# Altium schematic sheet mems.SchDoc(mems)
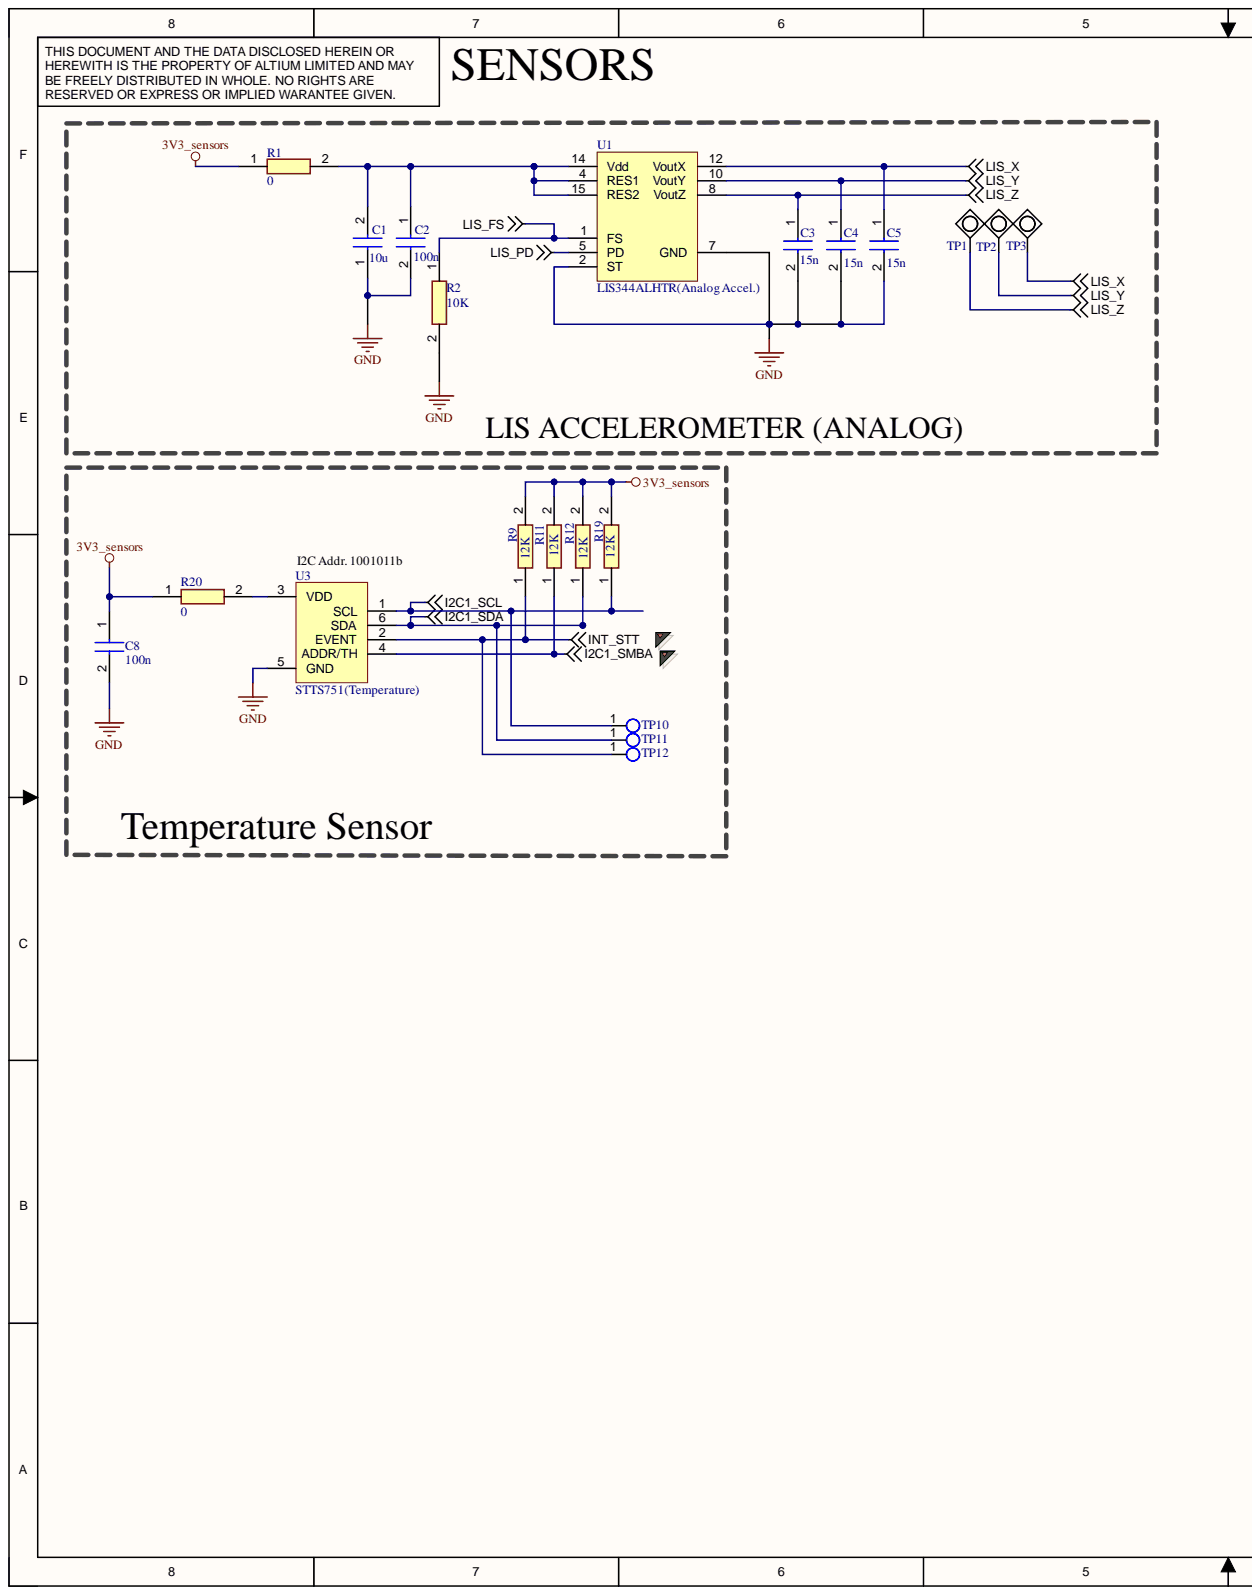
[diagram p0: mems.SchDoc, left]
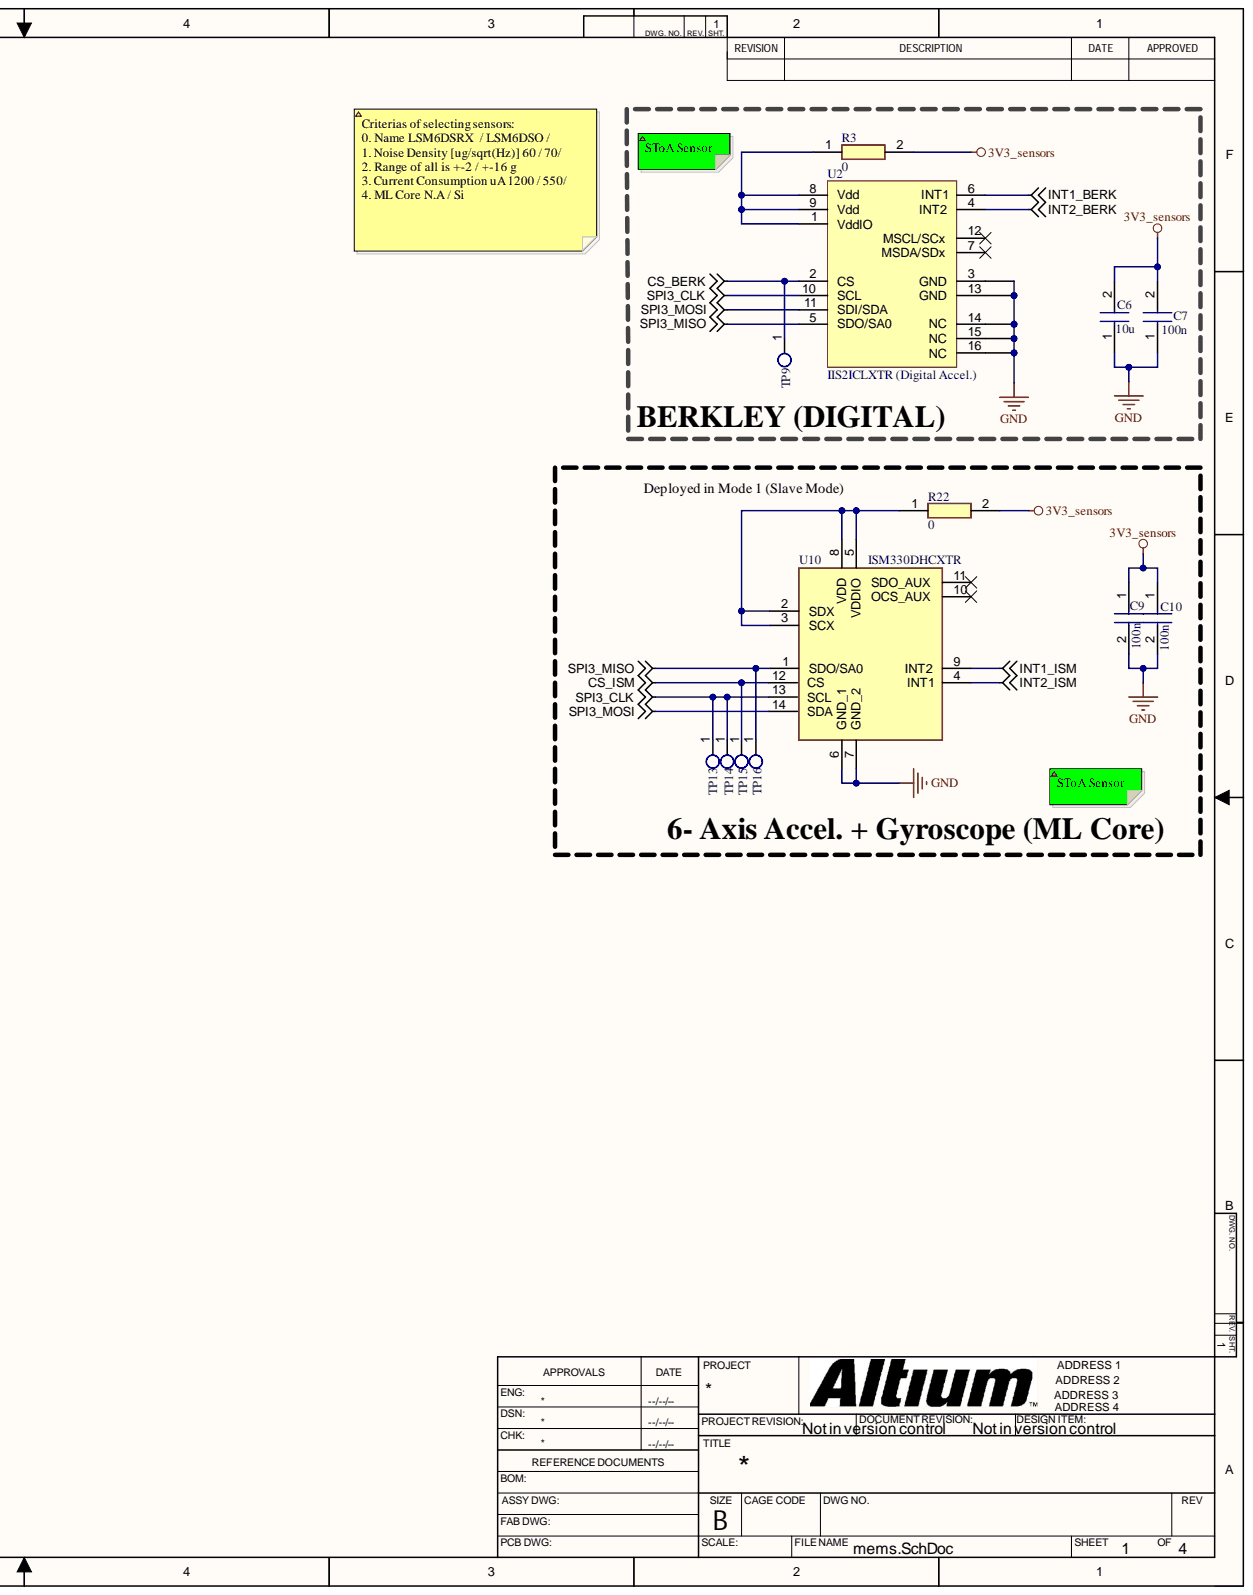
[diagram p1: mems.SchDoc, right]

## Parts
C1  10u  [CAPC1608X100N]
C2  100n  [CAPC1608X87N]
C3  15n  [CAPC1608X87N]
C4  15n  [CAPC1608X87N]
C5  15n  [CAPC1608X87N]
C6  10u  [CAPC1608X100N]
C7  100n  [CAPC1608X87N]
C8  100n  [CAPC1608X87N]
C9  100n  [CAPC1608X87N]
C10  100n  [CAPC1608X87N]
R1  0  [RESC1608X55N]
R2  10K  [ERJ3EK_(0603)]
R3  0  [RESC1608X55N]
R9  12K  [RESC0603X26N]
R11  12K  [RESC0603X26N]
R12  12K  [RESC0603X26N]
R19  12K  [RESC0603X26N]
R20  0  [RESC1608X55N]
R22  0  [RESC1608X55N]
TP1  Test Point  [KEYSTONE_5001]  (value not drawn)
TP2  Test Point  [KEYSTONE_5001]  (value not drawn)
TP3  Test Point  [KEYSTONE_5001]  (value not drawn)
TP9  test_point  [test_point]  (value not drawn)  (no BOM)
TP10  test_point  [test_point]  (value not drawn)  (no BOM)
TP11  test_point  [test_point]  (value not drawn)  (no BOM)
TP12  test_point  [test_point]  (value not drawn)  (no BOM)
TP13  test_point  [test_point]  (value not drawn)  (no BOM)
TP14  test_point  [test_point]  (value not drawn)  (no BOM)
TP15  test_point  [test_point]  (value not drawn)  (no BOM)
TP16  test_point  [test_point]  (value not drawn)  (no BOM)
U1  LIS344ALHTR(Analog Accel.)  [LGA16R65P4X4_400X400X160]
U2  IIS2ICLXTR (Digital Accel.)
U3  STTS751(Temperature)  [SOT95P280X145-6N]
U10  ISM330DHCXTR  [LGA-14(2.5X3X0.86)]

## Nets
3V3_sensors: C6.2 C7.2 C8.1 C9.1 C10.1 R1.1 R3.2 R9.2 R11.2 R12.2 R19.2 R20.1 R22.2
CS_BERK: TP9.1 U2.2(CS)
CS_ISM: TP15.1 U10.12(CS)
GND: C1.1 C2.2 C3.2 C4.2 C5.2 C6.1 C7.1 C8.2 C9.2 C10.2 R2.2 U1.2(ST) U1.7(GND) U2.3(GND) U2.13(GND) U2.14(NC) U2.15(NC) U2.16(NC) U3.5(GND) U10.6(GND_1) U10.7(GND_2)
I2C1_SCL: R19.1 TP10.1 U3.1(SCL)
I2C1_SDA: R12.1 TP11.1 U3.6(SDA)
I2C1_SMBA: R11.1 U3.4(ADDR/TH)
INT1_BERK: U2.6(INT1)
INT1_ISM: U10.9(INT2)
INT2_BERK: U2.4(INT2)
INT2_ISM: U10.4(INT1)
INT_STT: R9.1 TP12.1 U3.2(EVENT)
LIS_FS: R2.1 U1.1(FS)
LIS_PD: U1.5(PD)
LIS_X: C5.1 TP3.1 U1.12(VoutX)
LIS_Y: C4.1 TP2.1 U1.10(VoutY)
LIS_Z: C3.1 TP1.1 U1.8(VoutZ)
SPI3_CLK: TP13.1 TP14.1 U2.10(SCL) U10.13(SCL)
SPI3_MISO: TP16.1 U2.5(SDO/SA0) U10.1(SDO/SA0)
SPI3_MOSI: U2.11(SDI/SDA) U10.14(SDA)
NetC1_2: C1.2 C2.1 R1.2 U1.4(RES1) U1.14(Vdd) U1.15(RES2)
NetR3_1: R3.1 U2.1(VddIO) U2.8(Vdd) U2.9(Vdd)
NetR20_2: R20.2 U3.3(VDD)
NetR22_1: R22.1 U10.2(SDX) U10.3(SCX) U10.5(VDDIO) U10.8(VDD)

Not connected: U2 7(MSDA/SDx) 12(MSCL/SCx); U10 10(OCS_AUX) 11(SDO_AUX)

## Library data of the parts
C1 C6 = LMK107BBJ106KALT: Capacitor; Manufacturer_Name: TAIYO YUDEN; Component Kind: Standard; Datasheet Link: https://componentsearchengine.com//LMK107BBJ106KALT.pdf; Height: 1mm; Manufacturer_Part_Number: LMK107BBJ106KALT; Mouser2 Part Number: 963-LMK107BBJ106KALT; Mouser2 Price/Stock: https://www.mouser.com/Search/Refine.aspx?Keyword=963-LMK107BBJ106KALT
C2 C7-C10 = 885012206071: Capacitor; Manufacturer_Name: Wurth Elektronik; Component Kind: Standard; Datasheet Link: https://katalog.we-online.com/pbs/datasheet/885012206071.pdf; Height: 0.87mm; Manufacturer_Part_Number: 885012206071
C3-C5 = 885012206041: Capacitor; Manufacturer_Name: Wurth Elektronik; Component Kind: Standard; Datasheet Link: https://katalog.we-online.com/pbs/datasheet/885012206041.pdf; Height: 0.87mm; Manufacturer_Part_Number: 885012206041
R1 R3 R20 R22 = RC0603FR-070RL: Resistor; Manufacturer_Name: YAGEO (PHYCOMP); Component Kind: Standard; Height: 0.55mm; Manufacturer_Part_Number: RC0603FR-070RL
R2 = ERJ-3EKF1002V: Resistor; Manufacturer_Name: Panasonic; Component Kind: Standard; Datasheet Link: https://componentsearchengine.com//ERJ-3EKF1002V.pdf; Height: 0.55mm; Manufacturer_Part_Number: ERJ-3EKF1002V; Mouser2 Part Number: 667-ERJ-3EKF1002V; Mouser2 Price/Stock: https://www.mouser.com/Search/Refine.aspx?Keyword=667-ERJ-3EKF1002V
R9 R11 R12 R19 = ERJ-1RHD1202C: Resistor; Manufacturer_Name: Panasonic; Component Kind: Standard; Datasheet Link: https://industrial.panasonic.com/cdbs/www-data/pdf/RDA0000/AOA0000C304.pdf; Height: 0.26mm; Manufacturer_Part_Number: ERJ-1RHD1202C
TP1-TP3 = 5001: TEST POINT PC MINI .040D BLACK; Manufacturer: Keystone Electronics; Body Material: Bronze; Hole Diameter: 1.6mm; Inside Diameter: 1.27mm; Insulation: Insulated; Number of Contacts: 1; Outside Diameter: 2.54mm; Plating: Silver; Category: Test Probes, Leads and Clips; Color: Black; Component Kind: Standard; ComponentLink1Description: https://datasheet.ciiva.com/27052/1581986-27052611.pdf?src-supplier=Jameco; ComponentLink1URL: https://datasheet.ciiva.com/27052/1581986-27052611.pdf?src-supplier=Jameco; ComponentLink2Description: https://datasheet.ciiva.com/11836/0900766b8009b7c7-11836045.pdf?src-supplier=RSComponents; ComponentLink2URL: https://datasheet.ciiva.com/11836/0900766b8009b7c7-11836045.pdf?src-supplier=RSComponents; ComponentLink3Description: https://datasheet.ciiva.com/16318/m60-2p60-16318423.pdf?src-supplier=Future+Electronics; ComponentLink3URL: https://datasheet.ciiva.com/16318/m60-2p60-16318423.pdf?src-supplier=Future+Electronics; ComponentLink4Description: https://datasheet.ciiva.com/22631/1703983-22631100.pdf?src-supplier=Element14; ComponentLink4URL: https://datasheet.ciiva.com/22631/1703983-22631100.pdf?src-supplier=Element14; Contact Material: Bronze; Contact Plating: Silver,Tin; Diameter: 2.54mm; Flammability Rating: UL94 V-0; Height: 0.3inch; Housing Color: Black; Insulation Material: Nylon; Lead Free: Lead Free; Length: 7.62mm; Manufacturer Part Number: 5001; Material: Nylon,Bronze,Silver; Mount: Through Hole; Number of Ports: 1; Orientation: Straight; Package Quantity: 100; Packaging: Bulk; REACH SVHC: No SVHC; RoHS: Yes; RoHS Compliant: Yes; Series: THM; Stock 1: 647250; Supplier 1: Digi-Key; Supplier Part Number 1: 36-5001-ND; Termination: Through Hole; Library Name: shm_schem_lib.SchLib
U1 = LIS344ALHTR: 3-axis analog accelerometer, ±2 g / ±6 g user selectable full-scale, ultra compact, low power; Manufacturer: STMicroelectronics; Axis: X, Y, Z; Bandwidth: 2kHz; Max Supply Voltage: 3.6V; Min Supply Voltage: 2.4V; Nominal Supply Current: 680uA; Sensitivity: 15000mV/g; Case/Package: LGA; Category: Sensors; Component Kind: Standard; ComponentLink1Description: https://datasheet.ciiva.com/12174/cd00182781-85334-12174772.pdf?src-supplier=Mouser; ComponentLink1URL: https://datasheet.ciiva.com/12174/cd00182781-85334-12174772.pdf?src-supplier=Mouser; ComponentLink2Description: https://datasheet.ciiva.com/26928/lis344alhtr-26928753.pdf?src-supplier=IIIC; ComponentLink2URL: https://datasheet.ciiva.com/26928/lis344alhtr-26928753.pdf?src-supplier=IIIC; ComponentLink3Description: https://datasheet.ciiva.com/2809/cd00182781-2809847.pdf?src-supplier=Digi-Key; ComponentLink3URL: https://datasheet.ciiva.com/2809/cd00182781-2809847.pdf?src-supplier=Digi-Key; ComponentLink4Description: https://datasheet.ciiva.com/16372/cd00182781-16372423.pdf?src-supplier=Future+Electronics; ComponentLink4URL: https://datasheet.ciiva.com/16372/cd00182781-16372423.pdf?src-supplier=Future+Electronics; ComponentLink5Description: https://datasheet.ciiva.com/2809/cd00182781-2809848.pdf?src-supplier=Digi-Key; ComponentLink5URL: https://datasheet.ciiva.com/2809/cd00182781-2809848.pdf?src-supplier=Digi-Key; ComponentLink6Description: https://datasheet.ciiva.com/2809/cd00182781-2809849.pdf?src-supplier=Digi-Key; ComponentLink6URL: https://datasheet.ciiva.com/2809/cd00182781-2809849.pdf?src-supplier=Digi-Key; ComponentLink7Description: https://datasheet.ciiva.com/16638/cd00182781-16638187.pdf?src-supplier=Avnet+Europe; ComponentLink7URL: https://datasheet.ciiva.com/16638/cd00182781-16638187.pdf?src-supplier=Avnet+Europe; Contact Plating: Gold; Height: 1.33mm; Lead Free: Lead Free; Length: 4.15mm; Manufacturer Part Number: LIS344ALHTR; Max Operating Temperature: 85°C; Min Operating Temperature: -40°C; Mount: Surface Mount; Number of Pins: 16; Output Type: Analog; Package Quantity: 1; Packaging: Tape and Reel; Radiation Hardening: No; RoHS: Yes; Stock 1: 153; Supplier 1: Digi-Key; Supplier Part Number 1: 497-6345-1-ND; Width: 4.15mm; Library Name: shm_schem_lib.SchLib
U2 = IIS2ICLXTR: High-accuracy, high-resolution, low-power, 2- axis digital inclinometer; Manufacturer: STMicroelectronics; Category: Accelerometers; Component Kind: Standard; Manufacturer Part Number: IIS2ICLXTR; RoHS: Yes; Stock 1: 25; Supplier 1: Digi-Key; Supplier Part Number 1: 497-IIS2ICLXCT-ND; Library Name: shm_schem_lib.SchLib
U3 = STTS751-1DP3F: SENSOR TEMPERATURE SMBUS 6UDFN; Manufacturer: STMicroelectronics; Interface: I2C,SMBus,2-Wire,Serial; Max Output Current: 20mA; Max Supply Voltage: 3.6V; Min Supply Voltage: 2.25V; Min Supply Voltage (DC): 2V; Operating Supply Current: 50uA; Output Type: Digital; Resolution: 12b; Category: Sensors; Component Kind: Standard; ComponentLink1Description: https://datasheet.ciiva.com/5299/cd00252523-68863-5299731.pdf?src-supplier=Mouser; ComponentLink1URL: https://datasheet.ciiva.com/5299/cd00252523-68863-5299731.pdf?src-supplier=Mouser; ComponentLink2Description: https://datasheet.ciiva.com/2003/cd00252523-2003053.pdf?src-supplier=Digi-Key; ComponentLink2URL: https://datasheet.ciiva.com/2003/cd00252523-2003053.pdf?src-supplier=Digi-Key; ComponentLink3Description: https://datasheet.ciiva.com/2003/cd00252523-2003054.pdf?src-supplier=Digi-Key; ComponentLink3URL: https://datasheet.ciiva.com/2003/cd00252523-2003054.pdf?src-supplier=Digi-Key; ComponentLink4Description: https://datasheet.ciiva.com/16635/cd00252523-16635462.pdf?src-supplier=Avnet+Europe; ComponentLink4URL: https://datasheet.ciiva.com/16635/cd00252523-16635462.pdf?src-supplier=Avnet+Europe; ComponentLink5Description: https://datasheet.ciiva.com/2003/cd00252523-2003052.pdf?src-supplier=Digi-Key; ComponentLink5URL: https://datasheet.ciiva.com/2003/cd00252523-2003052.pdf?src-supplier=Digi-Key; Lead Free: Lead Free; Manufacturer Part Number: STTS751-1DP3F; Max Operating Temperature: 125°C; Max Supply Voltage (DC): 3.6V; Min Operating Temperature: -40°C; Mount: Surface Mount; Number of Pins: 6; Output Current: 20mA; Package Quantity: 3000; Packaging: Tape and Reel; Radiation Hardening: No; RoHS: Yes; RoHS Compliant: Yes; Series: STTS751; Stock 1: 1; Supplier 1: RSComponents; Supplier Part Number 1: 1908454; Library Name: shm_schem_lib.SchLib
U10 = ISM330DHCXTR: iNEMO inertial Sensor include 3D accelerometer & 3D gyroscope; LGA-14L package; Manufacturer: STMicroelectronics; Category: Inertial Measurement Units (IMUs); Component Kind: Standard; Manufacturer Part Number: ISM330DHCXTR; RoHS: Yes; Stock 1: 9794; Supplier 1: Digi-Key; Supplier Part Number 1: 497-19784-1-ND; Library Name: shm_schem_lib.SchLib
TP9-TP16 = test_point: Library Name: shm_schem_lib.SchLib
